# Altium schematic sheet board_sheet5.SchDoc
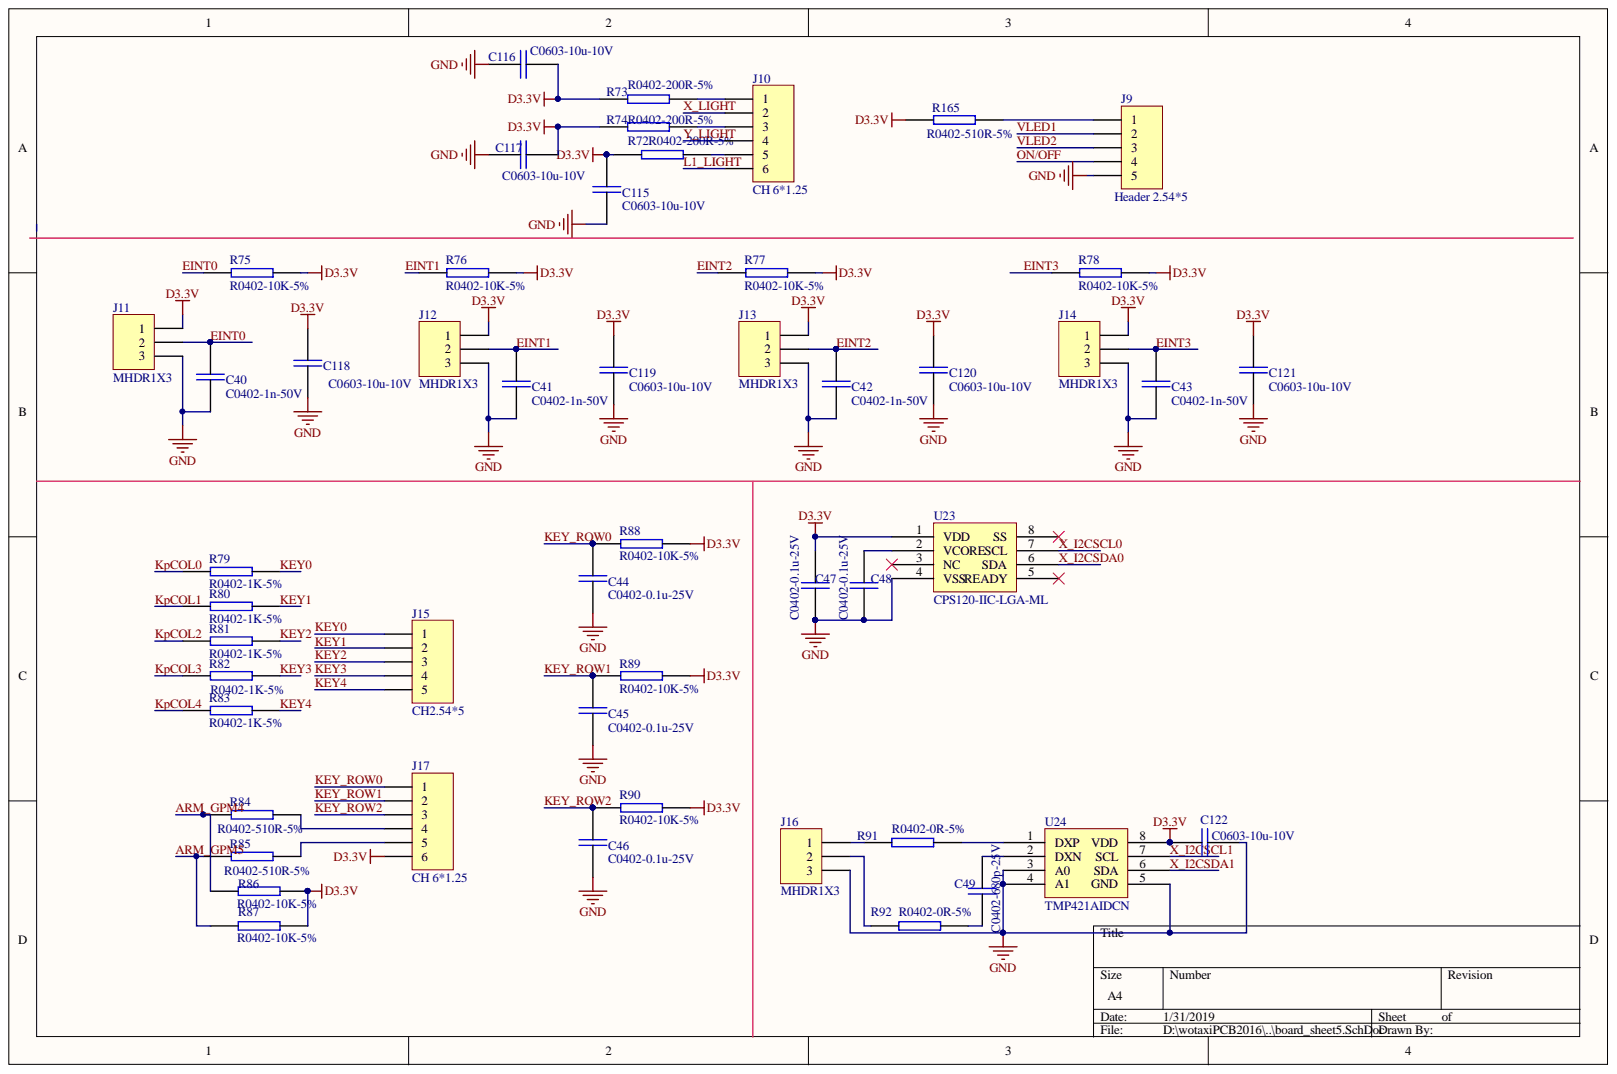
[diagram p0: board_sheet5.SchDoc]

## Parts
C40  C0402-1n-50V  [0402]
C41  C0402-1n-50V  [0402]
C42  C0402-1n-50V  [0402]
C43  C0402-1n-50V  [0402]
C44  C0402-0.1u-25V  [0402]
C45  C0402-0.1u-25V  [0402]
C46  C0402-0.1u-25V  [0402]
C47  C0402-0.1u-25V  [0402]
C48  C0402-0.1u-25V  [0402]
C49  C0402-680p-25V  [0402]
C115  C0603-10u-10V  [0603]
C116  C0603-10u-10V  [0603]
C117  C0603-10u-10V  [0603]
C118  C0603-10u-10V  [0603]
C119  C0603-10u-10V  [0603]
C120  C0603-10u-10V  [0603]
C121  C0603-10u-10V  [0603]
C122  C0603-10u-10V  [0603]
J9  Header 2.54*5  [TX-5*1.25-A]
J10  CH 6*1.25  [HD1.25*6]
J11  MHDR1X3  [TX-3*1.25-A]
J12  MHDR1X3  [TX-3*1.25-A]
J13  MHDR1X3  [TX-3*1.25-A]
J14  MHDR1X3  [TX-3*1.25-A]
J15  CH2.54*5  [HD1.25*5]
J16  MHDR1X3  [TX-3*1.25-A]
J17  CH 6*1.25  [HD1.25*6]
R72  R0402-200R-5%  [0402]
R73  R0402-200R-5%  [0402]
R74  R0402-200R-5%  [0402]
R75  R0402-10K-5%  [0402]
R76  R0402-10K-5%  [0402]
R77  R0402-10K-5%  [0402]
R78  R0402-10K-5%  [0402]
R79  R0402-1K-5%  [0402]
R80  R0402-1K-5%  [0402]
R81  R0402-1K-5%  [0402]
R82  R0402-1K-5%  [0402]
R83  R0402-1K-5%  [0402]
R84  R0402-510R-5%  [0402]
R85  R0402-510R-5%  [0402]
R86  R0402-10K-5%  [0402]
R87  R0402-10K-5%  [0402]
R88  R0402-10K-5%  [0402]
R89  R0402-10K-5%  [0402]
R90  R0402-10K-5%  [0402]
R91  R0402-0R-5%  [0402]
R92  R0402-0R-5%  [0402]
R165  R0402-510R-5%  [0402]
U23  CPS120-IIC-LGA-ML  [LGA-8]
U24  TMP421AIDCN  [TMP421]

## Nets
D3.3V: C47.2 C115.1 C116.1 C117.2 C118.1 C119.1 C120.1 C121.1 C122.1 J11.1 J12.1 J13.1 J14.1 J17.6 R72.2 R73.1 R74.1 R75.2 R76.2 R77.2 R78.2 R86.2 R87.2 R88.2 R89.2 R90.2 R165.2 U23.1(VDD) U24.8(VDD)
GND: C40.2 C41.2 C42.2 C43.2 C44.2 C45.2 C46.2 C47.1 C48.1 C115.2 C116.2 C117.1 C118.2 C119.2 C120.2 C121.2 C122.2 J9.5 J11.3 J12.3 J13.3 J14.3 J16.3 U23.4(VSS) U24.3(A0) U24.4(A1) U24.5(GND)
ARM_GPM4: R84.1 R86.1
ARM_GPM5: R85.1 R87.1
EINT0: C40.1 J11.2 R75.1
EINT1: C41.1 J12.2 R76.1
EINT2: C42.1 J13.2 R77.1
EINT3: C43.1 J14.2 R78.1
KEY0: J15.1 R79.2
KEY1: J15.2 R80.2
KEY2: J15.3 R81.2
KEY3: J15.4 R82.2
KEY4: J15.5 R83.2
KEY_ROW0: C44.1 J17.1 R88.1
KEY_ROW1: C45.1 J17.2 R89.1
KEY_ROW2: C46.1 J17.3 R90.1
KpCOL0: R79.1
KpCOL1: R80.1
KpCOL2: R81.1
KpCOL3: R82.1
KpCOL4: R83.1
L1_LIGHT: J10.6
ON/OFF: J9.4
VLED1: J9.2
VLED2: J9.3
X_I2CSCL0: U23.7(SCL)
X_I2CSCL1: U24.7(SCL)
X_I2CSDA0: U23.6(SDA)
X_I2CSDA1: U24.6(SDA)
X_LIGHT: J10.2
Y_LIGHT: J10.4
NetC48_2: C48.2 U23.2(VCORE)
NetC49_1: C49.1 U24.2(DXN)
NetC49_2: C49.2 R92.2
NetJ9_1: J9.1 R165.1
NetJ10_1: J10.1 R73.2
NetJ10_3: J10.3 R74.2
NetJ10_5: J10.5 R72.1
NetJ16_1: J16.1 R91.1
NetJ16_2: J16.2 R92.1
NetJ17_4: J17.4 R84.2
NetJ17_5: J17.5 R85.2
NetR91_2: R91.2 U24.1(DXP)
N00242:

Not connected: U23 3(NC) 5(READY) 8(SS)

## Library data of the parts
C40-C49 C115-C122 = CAP: Component Kind: Standard; Library Name: KL300-CONTROLER.SCHLIB
J9 J15 = MHDR1X5: Component Kind: Standard; Library Name: KL300-POWER.SCHLIB
J10 J17 = MHDR1X6: Component Kind: Standard; Library Name: KL300-POWER.SCHLIB
J11-J14 J16 = MHDR1X3: Header, 3-Pin; Component Kind: Standard; LatestRevisionDate: 17-Jul-2002; LatestRevisionNote: Re-released for DXP Platform.; Library Name: Miscellaneous Connectors.IntLib; Signal Integrity: Connector; Publisher: Altium Limited
R72-R92 R165 = RES: Component Kind: Standard; Library Name: KL300-CONTROLER.SCHLIB
U23 = CPS120: Component Kind: Standard; Library Name: KL300-CONTROLER.SCHLIB
U24 = TMP421: Component Kind: Standard; Library Name: KL300-CONTROLER.SCHLIB
